# Altium schematic sheet [6] Oscillateur.SchDoc("[6] Oscillateur")
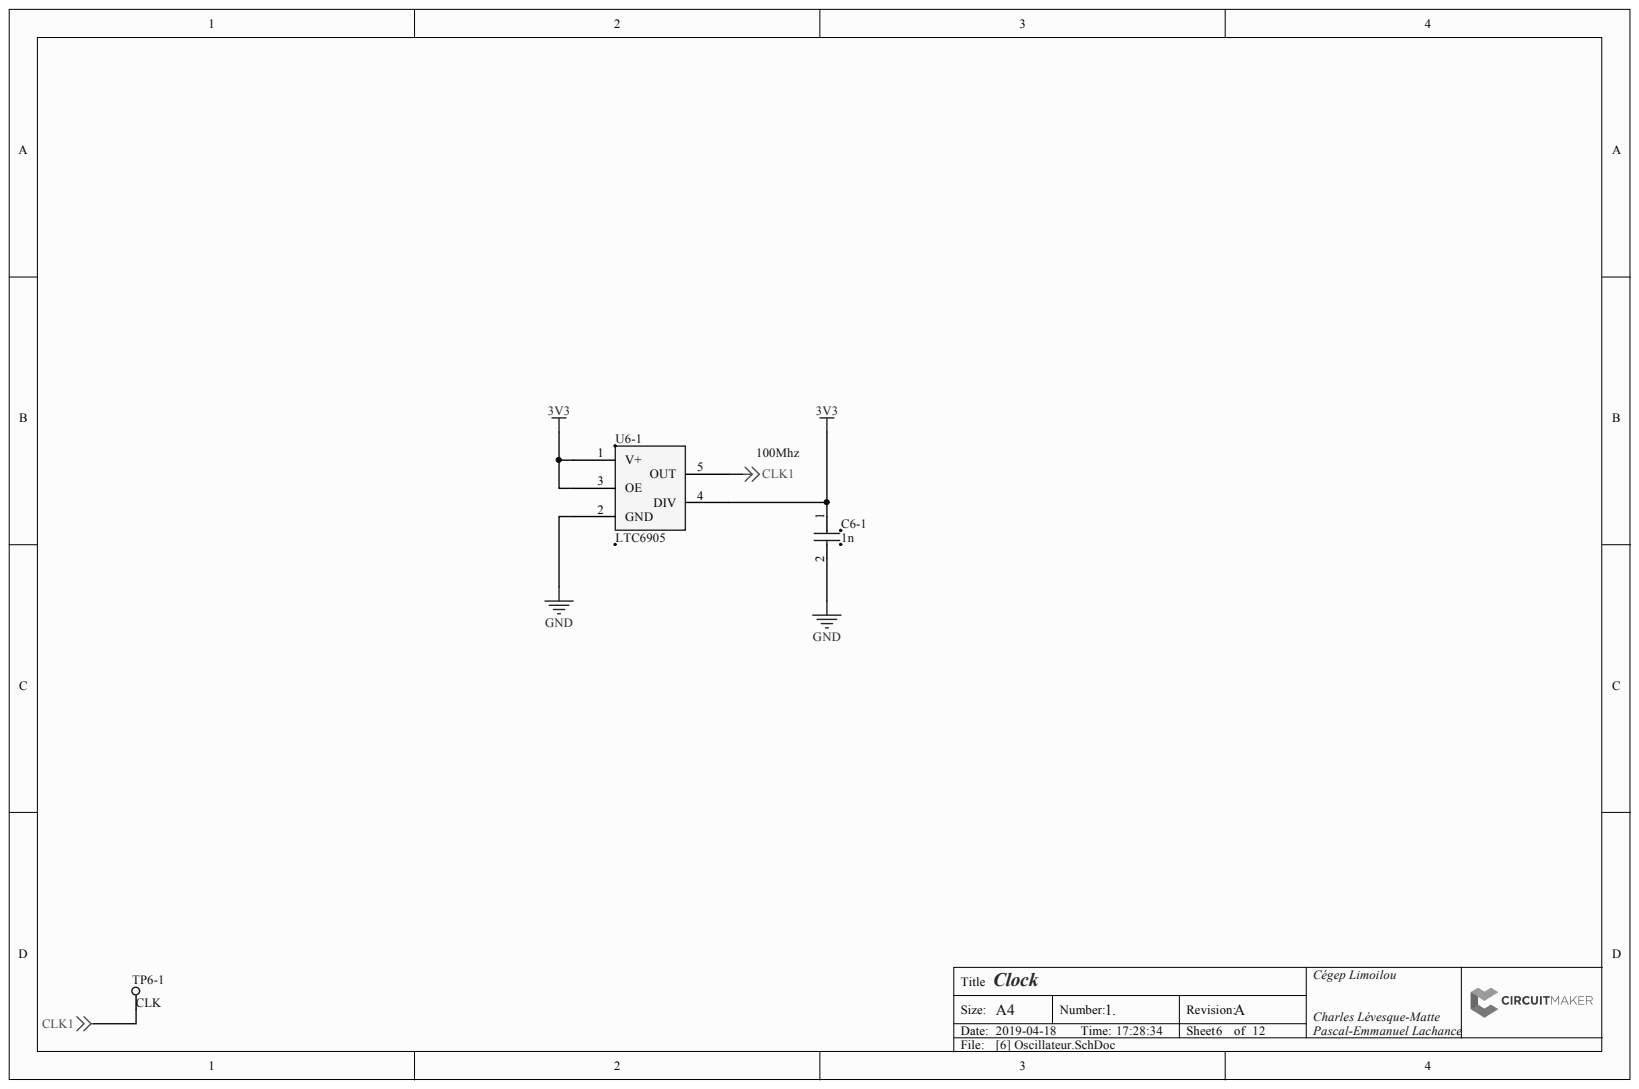
[diagram p0: [6] Oscillateur.SchDoc]

## Parts
C6-1  1n  [0603C_B]
TP6-1  CLK  [PCB-a7rpxwhzqdkz91v608la-1]
U6-1  LTC6905  [PCB-epjth0sw10fwgvcfau74-1]

## Nets
3V3: C6-1.1 U6-1.1(V+) U6-1.3(OE) U6-1.4(DIV)
CLK1: TP6-1.1 U6-1.5(OUT)
GND: C6-1.2 U6-1.2(GND)

## Library data of the parts
C6-1 = CMP-bbc372cb6f006404-3: Manufacturer Part Number: CC0603KRX7R9BB102; Capacitance: 1.00 nF; Capacitance Tolerance: ±10%; Dielectric Characteristic: X7R; Insulation Resistance: 100 GΩ; Lead-Free Status: Lead Free; Voltage Rating (DC): 50.0 V; Case/Package: 0603; Component Kind: Standard; Halogen Free Status: Halogen Free; Library Name: CommunityVault; Mounting Style: Surface Mount; Number of Pins: 2; OctopartID: bbc372cb6f006404; Octopart Url: http://octopart.com/cc0603krx7r9bb102-yageo-192933; Packaging: Cut Tape (CT), Tape & Reel (TR); REACH SVHC Compliance: No SVHC; RoHS: Compliant; Seller Landing  Url: https://octopart-clicks.com/click/track?country=US&ak=8157f166&sig=010f1ca&sid=1106&ppid=192933&vpid=198824049&ct=offers; Size-Height: 810 µm; Size-Length: 1.60 mm; Size-Width: 810 µm
TP6-1 = CMP-5j384tb079h9dvgbp04a-2: Through-hole Testpoint; Component Kind: Standard; Library Name: CommunityVault
U6-1 = CMP-7ec0b7cdd25da107-1: Manufacturer Part Number: LTC6905CS5#TRMPBF; Frequency: 170 MHz; Lead-Free Status: Lead Free; Supply Voltage (DC): 5.00 V, 5.50 V (max); Component Kind: Standard; Library Name: CommunityVault; Mounting Style: Surface Mount; Number of Pins: 5; OctopartID: 7ec0b7cdd25da107; Octopart URL: http://octopart.com/ltc6905cs5%23trmpbf-linear+technology-494075; Packaging: Cut Tape (CT); REACH SVHC Compliance: No SVHC; RoHS: Compliant
